# Altium schematic sheet AquaboxII.SchDoc
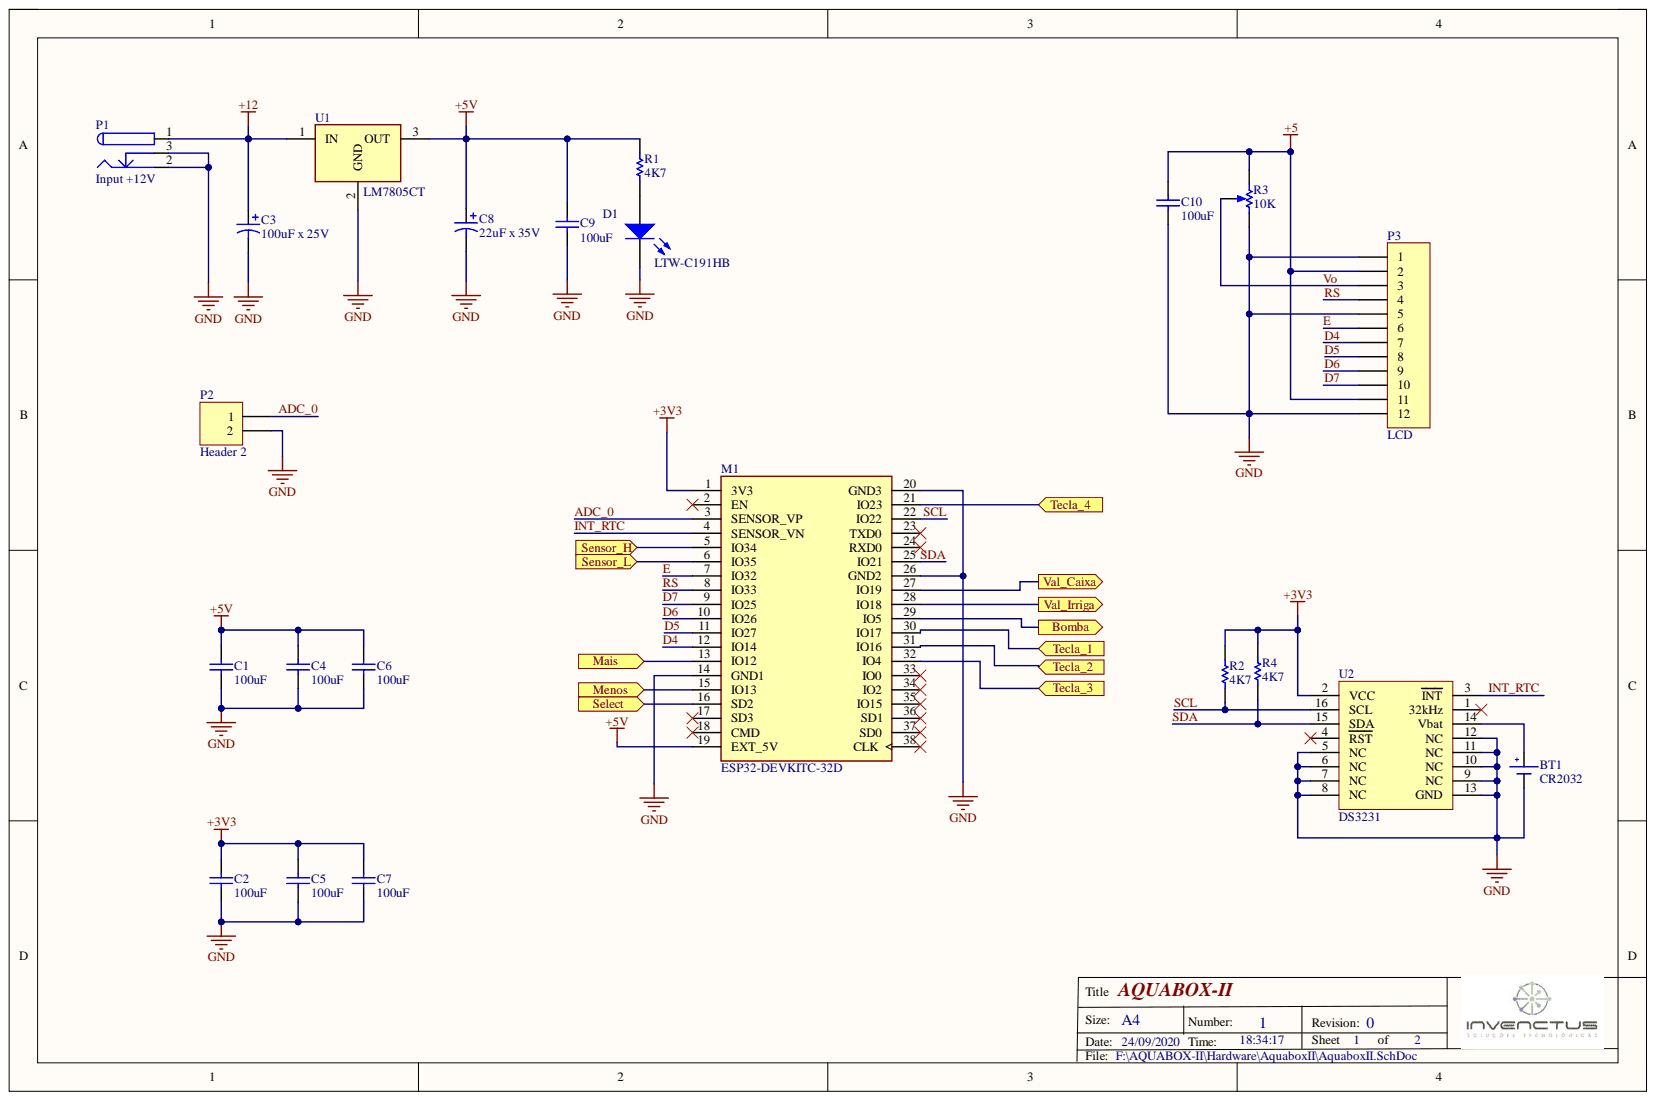
[diagram p0: AquaboxII.SchDoc]

## Parts
BT1  CR2032  [BAT CR2032]
C1  100uF  [C2012[0805]]
C2  100uF  [C2012[0805]]
C3  100uF x 25V  [Cap_Al_SMD_C6_6.6x6.6mm]
C4  100uF  [C2012[0805]]
C5  100uF  [C2012[0805]]
C6  100uF  [C2012[0805]]
C7  100uF  [C2012[0805]]
C8  22uF x 35V  [Cap_Al_SMD_C6_6.6x6.6mm]
C9  100uF  [C2012[0805]]
C10  100uF  [C2012[0805]]
D1  LTW-C191HB  [LED-0603-R]
M1  ESP32-DEVKITC-32D  [MODULE_ESP32-DEVKITC-32D]
P1  Input +12V  [BARREL2.5]
P2  Header 2  [HDR1X2]
P3  LCD  [HDR1X12]
R1  4K7  [R2012[0805]]
R2  4K7  [R2012[0805]]
R3  10K  [VR6]
R4  4K7  [R2012[0805]]
U1  LM7805CT  [TO254P470X1028X1955-3P]
U2  DS3231  [SOIC-16 - W16 (300'')]

## Ports
Bomba, Mais, Menos, Select, Sensor_H, Sensor_L, Tecla_1, Tecla_2, Tecla_3, Tecla_4, Val_Caixa, Val_Irriga

## Nets
+3V3: C2.2 C5.2 C7.2 M1.1(3V3) R2.2 R4.2 U2.2(VCC)
+5: C10.2 P3.2 P3.11 R3.1
+5V: C1.2 C4.2 C6.2 C8.1 C9.2 M1.19(EXT_5V) R1.2 U1.3
+12: C3.1 P1.1 U1.1
GND: BT1.2 C1.1 C2.1 C3.2 C4.1 C5.1 C6.1 C7.1 C8.2 C9.1 C10.1 D1.2 M1.14(GND1) M1.20(GND3) M1.26(GND2) P1.2 P1.3 P2.2 P3.1 P3.5 P3.12 R3.2 U1.2 U2.5(NC) U2.6(NC) U2.7(NC) U2.8(NC) U2.9(NC) U2.10(NC) U2.11(NC) U2.12(NC) U2.13(GND)
ADC_0: M1.3(SENSOR_VP) P2.1
Bomba: M1.29(IO5) ; port Bomba
D4: M1.12(IO14) P3.7
D5: M1.11(IO27) P3.8
D6: M1.10(IO26) P3.9
D7: M1.9(IO25) P3.10
E: M1.7(IO32) P3.6
INT_RTC: M1.4(SENSOR_VN) U2.3(INT)
Mais: M1.13(IO12) ; port Mais
Menos: M1.15(IO13) ; port Menos
RS: M1.8(IO33) P3.4
SCL: M1.22(IO22) R2.1 U2.16(SCL)
SDA: M1.25(IO21) R4.1 U2.15(SDA)
Select: M1.16(SD2) ; port Select
Sensor_H: M1.5(IO34) ; port Sensor_H
Sensor_L: M1.6(IO35) ; port Sensor_L
Tecla_1: M1.30(IO17) ; port Tecla_1
Tecla_2: M1.31(IO16) ; port Tecla_2
Tecla_3: M1.32(IO4) ; port Tecla_3
Tecla_4: M1.21(IO23) ; port Tecla_4
Val_Caixa: M1.27(IO19) ; port Val_Caixa
Val_Irriga: M1.28(IO18) ; port Val_Irriga
Vo: P3.3 R3.3
NetBT1_1: BT1.1 U2.14(Vbat)
NetD1_1: D1.1 R1.1

Not connected: M1 2(EN) 17(SD3) 18(CMD) 23(TXD0) 24(RXD0) 33(IO0) 34(IO2) 35(IO15) 36(SD1) 37(SD0) 38(CLK); U2 1(32kHz) 4(RST)

## Library data of the parts
BT1 = Bat1: Singlecell Battery; Component Kind: Standard; Library Name: Basic Library.IntLib
C1 C2 C4-C7 C9 C10 = C: Capacitor; Value: 1; Component Kind: Standard; Library Name: Capacitores.IntLib; Simulation: CAP
C3 C8 = CP: Polarized Capacitor; Component Kind: Standard; Library Name: Capacitores.IntLib; Signal Integrity: Cap Pol; Simulation: CAP
D1 = LED: Component Kind: Standard; Description: LED; Library Name: Basic Library.IntLib; Simulation: LED0
M1 = ESP32-DEVKITC-32D: - Transceiver; 802.11 b/g/n _Wi-Fi, WiFi, WLAN_, BluetoothÂ® Smart Ready 4.x Dual Mode For Use With ESP-WROOM-32; MF: Espressif Systems; Availability: Unavailable; Component Kind: Standard; Library Name: Modulos_Library.IntLib; MP: ESP32-DEVKITC-32D; Package: None; Price: None; SnapEDA_Link: https://www.snapeda.com/parts/ESP32-DEVKITC-32D/Espressif%20Systems/view-part/2777395/?ref=snap
P1 = PWR2.5: Low Voltage Power Supply Connector; Manufacturer: Memory Protection Devices; Manufacturer Part Number: EJ503A; Component Kind: Standard; Library Name: Connectors Library.IntLib; Pricing 1: 1=2.7, 10=2.447, 100=2.0977, 500=1.74806, 1000=1.49834 (USD); Stock 1: 1084; Supplier 1: Digi-Key; Supplier Part Number 1: EJ503A-ND
P2 = Header 2: Header, 2-Pin; Component Kind: Standard; LatestRevisionDate: 17-Jul-2002; LatestRevisionNote: Re-released for DXP Platform.; Library Name: Miscellaneous Connectors.IntLib; Signal Integrity: Connector; Publisher: Altium Limited
P3 = Header 12: Header, 12-Pin; Component Kind: Standard; LatestRevisionDate: 17-Jul-2002; LatestRevisionNote: Re-released for DXP Platform.; Library Name: Miscellaneous Connectors.IntLib; Signal Integrity: Connector; Publisher: Altium Limited
R1 R2 R4 = R: Resistor; Value: 0; Component Kind: Standard; Signal Integrity: Res Semi; Simulation: RES; Library Name: Resistores.IntLib
R3 = R Pot: Potentiometer; Component Kind: Standard; Simulation: POT; Library Name: Resistores.IntLib
U1 = LM7805CT: Integrated Circuit; Manufacturer_Name: Texas Instruments; Manufacturer_Part_Number: LM7805CT/NOPB; Arrow Part Number: LM7805CT/NOPB; Arrow Price/Stock: https://www.arrow.com/en/products/lm7805ctnopb/texas-instruments; Component Kind: Standard; Datasheet Link: http://www.ti.com/lit/gpn/lm7800; Height: 4.7mm; Mouser Part Number: 926-LM7805CT/NOPB; Mouser Price/Stock: https://www.mouser.co.uk/ProductDetail/Texas-Instruments/LM7805CT-NOPB?qs=OYMYEaN9QmBS2GvaX6GSkQ%3D%3D; Library Name: Reguladores.IntLib
U2 = DS3231: DS3231 SO-16-300mil; Manufacturer: Maxim; Manufacturer Part Number: DS3231SN#T&R; Date Format: YY-MM-DD-dd; Interface: I2C,Serial,2-Wire; Logic Function: Clock; Max Supply Voltage: 5.5V; Min Supply Voltage: 2.3V; Operating Supply Voltage: 3.3V; Time Format: HH:MM:SS; Case/Package: SOIC; Component Kind: Standard; ComponentLink1Description: http://datasheets.maxim-ic.com/en/ds/DS3231.pdf?src-supplier=Avnet+Europe; ComponentLink1URL: http://datasheets.maxim-ic.com/en/ds/DS3231.pdf?src-supplier=Avnet+Europe; ComponentLink2Description: http://www.mouser.com/ds/2/256/DS3231-102175.pdf?src-supplier=Mouser; ComponentLink2URL: http://www.mouser.com/ds/2/256/DS3231-102175.pdf?src-supplier=Mouser; ComponentLink3Description: http://datasheets.maximintegrated.com/en/ds/DS3231.pdf?src-supplier=Avnet; ComponentLink3URL: http://datasheets.maximintegrated.com/en/ds/DS3231.pdf?src-supplier=Avnet; Contact Plating: Tin,Matte; Lead Free: Lead Free; Library Name: Components Library.IntLib; Max Operating Temperature: 85°C; Min Operating Temperature: -40°C; Mount: Surface Mount; Number of Pins: 16; Package Quantity: 1000; Packaging: Tape and Reel; Pricing 1: 1=3.2134, 10=2.3205, 30=2.1594, 100=2.0005, 500=1.8931, 1000=1.8752, 4000=1.8003, 12000=1.7628, 1=2.8965, 10=2.0916, 30=1.9464, 100=1.8031, 500=1.7064, 1000=1.6903, 4000=1.6228, 12000=1.5889, 1=15.5535, 10=11.2316, 30=10.4517, 100=9.6825, 500=9.1628, 1000=9.0763, 4000=8.7139, 12000=8.5323, 1=14.7991, 10=10.6868, 30=9.9448, 100=9.2129, 500=8.7184, 1000=8.6361, 4000=8.2913, 12000=8.1185, 1=1.9816, 10=1.431, 30=1.3316, 100=1.2336, 500=1.1674, 1000=1.1564, 4000=1.1102, 12000=1.0871, 1=1.7641, 10=1.2739, 30=1.1855, 100=1.0982, 500=1.0393, 1000=1.0295, 4000=0.9884, 12000=0.9678, 1=17.0576, 10=12.3177, 30=11.4624, 100=10.6189, 500=10.0489, 1000=9.9541, 4000=9.5566, 12000=9.3575, 1=232.691, 10=168.032, 30=156.3643, 100=144.857, 500=137.0821, 1000=135.7881, 4000=130.366, 12000=127.6496, 1=9.107, 10=6.5764, 30=6.1198, 100=5.6694, 500=5.3651, 1000=5.3145, 4000=5.1022, 12000=4.9959, 1=140.6887, 10=101.5949, 30=94.5404, 100=87.5829, 500=82.8821, 1000=82.0997, 4000=78.8214, 12000=77.179, 1=21.4123, 10=15.4623, 30=14.3887, 100=13.3298, 500=12.6143, 1000=12.4952, 4000=11.9963, 12000=11.7463, 1=3.0003, 10=2.1666, 30=2.0161, 100=1.8678, 500=1.7675, 1000=1.7508, 4000=1.6809, 12000=1.6459, 1=2.1758, 10=1.5712, 30=1.4621, 100=1.3545, 500=1.2818, 1000=1.2697, 4000=1.219, 12000=1.1936 (AUD); Radiation Hardening: No; Stock 1: 18844; Supplier 1: LCSC; Supplier Part Number 1: C9866
